# Altium schematic sheet WGPIB.SchDoc(WGPIB)
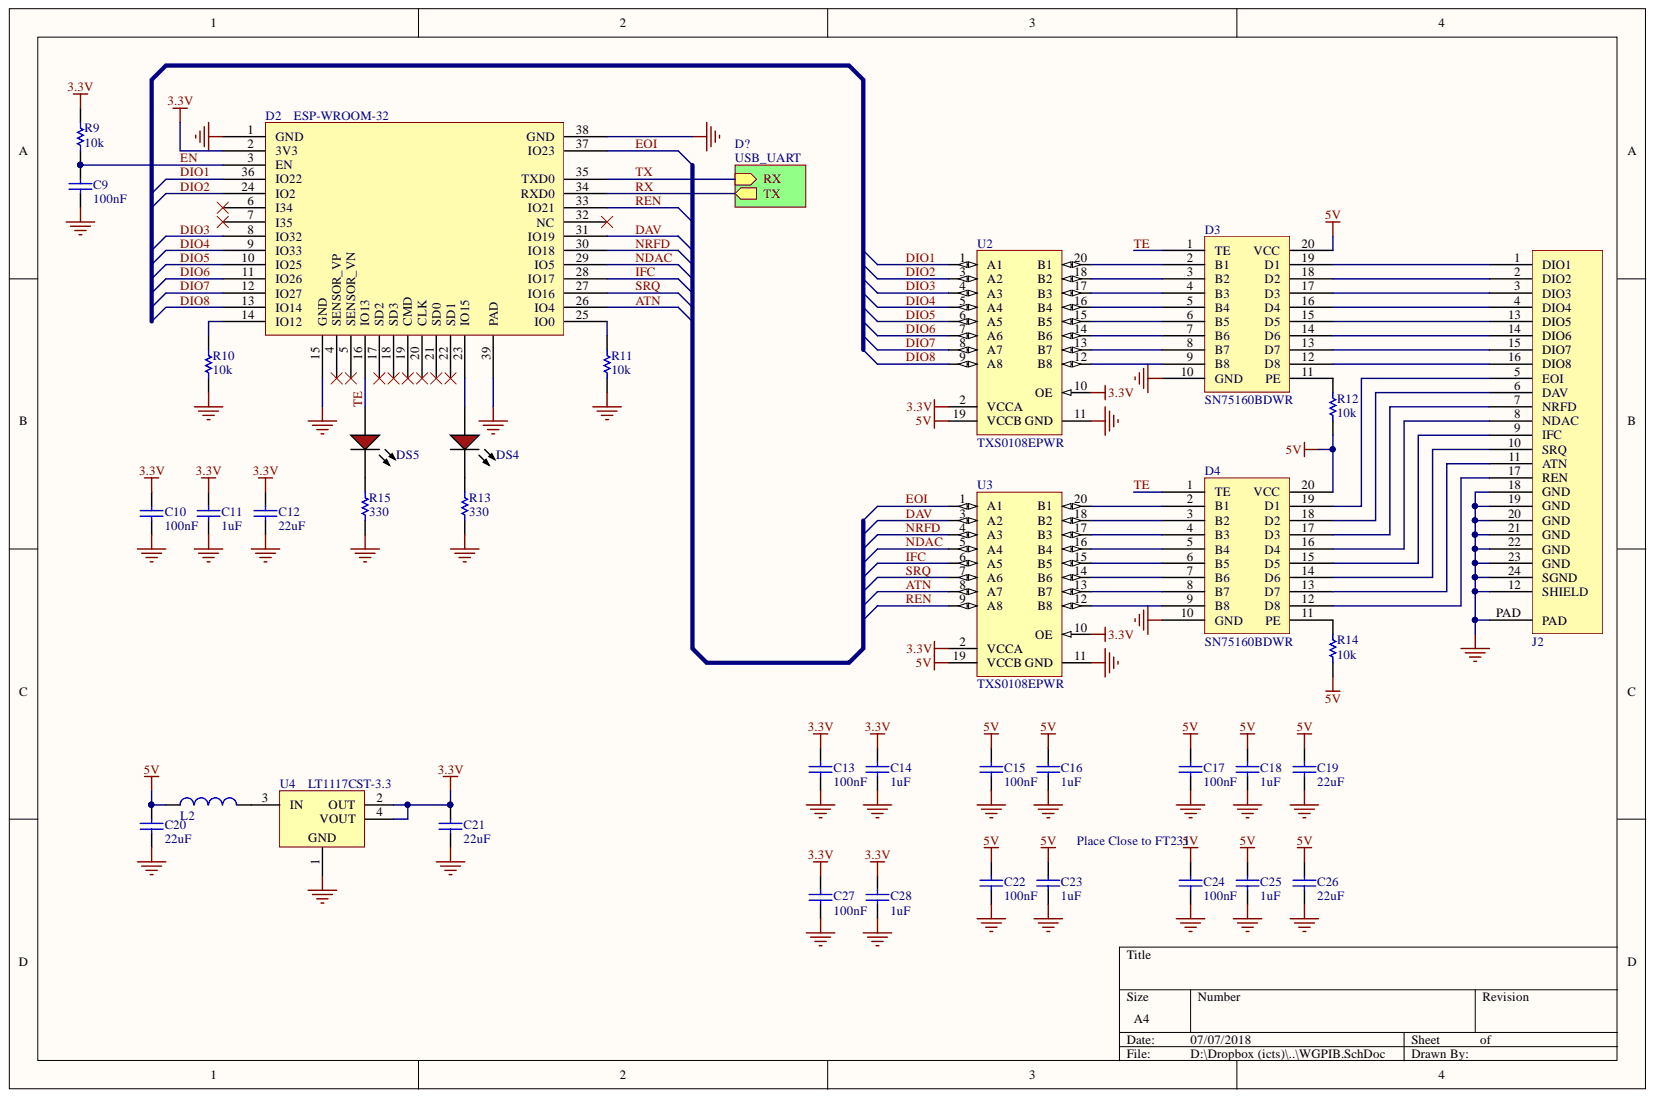
[diagram p0: WGPIB.SchDoc]

## Parts
C9  100nF  [CAPC0805(2012)145_N]
C10  100nF  [CAPC0805(2012)145_N]
C11  1uF  value 100nF  [CAPC0805(2012)145_N]
C12  22uF  [CAPC1206(3216)190_M]
C13  100nF  [CAPC0805(2012)145_N]
C14  1uF  value 100nF  [CAPC0805(2012)145_N]
C15  100nF  [CAPC0805(2012)145_N]
C16  1uF  value 100nF  [CAPC0805(2012)145_N]
C17  100nF  [CAPC0805(2012)145_N]
C18  1uF  value 100nF  [CAPC0805(2012)145_N]
C19  22uF  [CAPC1206(3216)190_M]
C20  22uF  [CAPC1206(3216)190_M]
C21  22uF  [CAPC1206(3216)190_M]
C22  100nF  [CAPC0805(2012)145_N]
C23  1uF  value 100nF  [CAPC0805(2012)145_N]
C24  100nF  [CAPC0805(2012)145_N]
C25  1uF  value 100nF  [CAPC0805(2012)145_N]
C26  22uF  [CAPC1206(3216)190_M]
C27  100nF  [CAPC0805(2012)145_N]
C28  1uF  value 100nF  [CAPC0805(2012)145_N]
D2  ESP-WROOM-32  [ESP-WROOM-32]
D3  SN75160BDWR  [SOIC-20]
D4  SN75160BDWR  [SOIC-20]
DS4  TLMS1100-GS08  [VISH-TLMX1100_V]  (value not drawn)
DS5  TLMS1100-GS08  [VISH-TLMX1100_V]  (value not drawn)
J2  GPIB  [GPIB THT]  (value not drawn)
L2  FBMJ2125HM210NT  [INDC2013X105X50LL20T30]  (value not drawn)
R9  10k  [RESC0805(2012)_M]
R10  10k  [RESC0805(2012)_M]
R11  10k  [RESC0805(2012)_M]
R12  10k  [RESC0805(2012)_M]
R13  330  [RESC0805(2012)_M]
R14  10k  [RESC0805(2012)_M]
R15  330  [RESC0805(2012)_M]
U2  TXS0108EPWR  [PW0020A_M]
U3  TXS0108EPWR  [PW0020A_M]
U4  LT1117CST-3.3  [LT-ST-3-SOT-223_M]

## Nets
3.3V: C10.2 C11.2 C12.2 C13.2 C14.2 C21.2 C27.2 C28.2 D2.2(3V3) R9.2 U2.2(VCCA) U2.10(OE) U3.2(VCCA) U3.10(OE) U4.2(OUT) U4.4(VOUT)
5V: C15.2 C16.2 C17.2 C18.2 C19.2 C20.2 C22.2 C23.2 C24.2 C25.2 C26.2 D3.20(VCC) D4.20(VCC) L2.1 R12.1 R14.1 U2.19(VCCB) U3.19(VCCB)
GND: C9.1 C10.1 C11.1 C12.1 C13.1 C14.1 C15.1 C16.1 C17.1 C18.1 C19.1 C20.1 C21.1 C22.1 C23.1 C24.1 C25.1 C26.1 C27.1 C28.1 D2.1(GND) D2.15(GND) D2.38(GND) D2.39(PAD) D3.10(GND) D4.10(GND) J2.12(SHIELD) J2.18(GND) J2.19(GND) J2.20(GND) J2.21(GND) J2.22(GND) J2.23(GND) J2.24(SGND) J2.PAD R10.1 R11.1 R13.1 R15.1 U2.11(GND) U3.11(GND) U4.1
ATN: D2.26(IO4) U3.8(A7)
DAV: D2.31(IO19) U3.3(A2)
DIO1: D2.36(IO22) U2.1(A1)
DIO2: D2.24(IO2) U2.3(A2)
DIO3: D2.8(IO32) U2.4(A3)
DIO4: D2.9(IO33) U2.5(A4)
DIO5: D2.10(IO25) U2.6(A5)
DIO6: D2.11(IO26) U2.7(A6)
DIO7: D2.12(IO27) U2.8(A7)
DIO8: D2.13(IO14) U2.9(A8)
EN: C9.2 D2.3(EN) R9.1
EOI: D2.37(IO23) U3.1(A1)
IFC: D2.28(IO17) U3.6(A5)
NDAC: D2.29(IO5) U3.5(A4)
NRFD: D2.30(IO18) U3.4(A3)
REN: D2.33(IO21) U3.9(A8)
RX: D2.34(RXD0)
SRQ: D2.27(IO16) U3.7(A6)
TE: D2.16(IO13) D3.1(TE) D4.1(TE) DS5.1
TX: D2.35(TXD0)
NetD2_4: D2.4(SENSOR_VP)
NetD2_5: D2.5(SENSOR_VN)
NetD2_6: D2.6(I34)
NetD2_7: D2.7(I35)
NetD2_14: D2.14(IO12) R10.2
NetD2_17: D2.17(SD2)
NetD2_18: D2.18(SD3)
NetD2_19: D2.19(CMD)
NetD2_20: D2.20(CLK)
NetD2_21: D2.21(SD0)
NetD2_22: D2.22(SD1)
NetD2_23: D2.23(IO15) DS4.1
NetD2_25: D2.25(IO0) R11.2
NetD2_32: D2.32(NC)
NetD3_2: D3.2(B1) U2.20(B1)
NetD3_3: D3.3(B2) U2.18(B2)
NetD3_4: D3.4(B3) U2.17(B3)
NetD3_5: D3.5(B4) U2.16(B4)
NetD3_6: D3.6(B5) U2.15(B5)
NetD3_7: D3.7(B6) U2.14(B6)
NetD3_8: D3.8(B7) U2.13(B7)
NetD3_9: D3.9(B8) U2.12(B8)
NetD3_11: D3.11(PE) R12.2
NetD3_12: D3.12(D8) J2.16(DIO8)
NetD3_13: D3.13(D7) J2.15(DIO7)
NetD3_14: D3.14(D6) J2.14(DIO6)
NetD3_15: D3.15(D5) J2.13(DIO5)
NetD3_16: D3.16(D4) J2.4(DIO4)
NetD3_17: D3.17 J2.3(DIO3)
NetD3_18: D3.18(D2) J2.2(DIO2)
NetD3_19: D3.19(D1) J2.1(DIO1)
NetD4_2: D4.2(B1) U3.20(B1)
NetD4_3: D4.3(B2) U3.18(B2)
NetD4_4: D4.4(B3) U3.17(B3)
NetD4_5: D4.5(B4) U3.16(B4)
NetD4_6: D4.6(B5) U3.15(B5)
NetD4_7: D4.7(B6) U3.14(B6)
NetD4_8: D4.8(B7) U3.13(B7)
NetD4_9: D4.9(B8) U3.12(B8)
NetD4_11: D4.11(PE) R14.2
NetD4_12: D4.12(D8) J2.17(REN)
NetD4_13: D4.13(D7) J2.11(ATN)
NetD4_14: D4.14(D6) J2.10(SRQ)
NetD4_15: D4.15(D5) J2.9(IFC)
NetD4_16: D4.16 J2.8(NDAC)
NetD4_17: D4.17(D3) J2.7(NRFD)
NetD4_18: D4.18(D2) J2.6(DAV)
NetD4_19: D4.19(D1) J2.5(EOI)
NetDS4_2: DS4.2 R13.2
NetDS5_2: DS5.2 R15.2
NetL2_2: L2.2 U4.3(IN)
N00038:
N00039:

## Library data of the parts
C9-C11 C13-C18 C22-C25 C27 C28 = CMP-1036-04431-1: Tolerance: Â±20%; Rated Voltage: 100 V; Case-Metric: 2012; Case-EIA: 0805; Technology: SMT; Max Thickness: 1.45 mm; Library Name: Altium Content Vault; Component Kind: Standard
  C9 C10 C13 C15 C17 C22 C24 C27: Value: 100nF
C12 C19-C21 C26 = CMP-1037-04993-1: Tolerance: Â±20%; Rated Voltage: 16 V; Case-Metric: 3216; Case-EIA: 1206; Value: 22uF; Technology: SMT; Max Thickness: 1.9 mm; Library Name: Altium Content Vault; Component Kind: Standard
D2 = ESP-WROOM-32: ESP32 Module; Library Name: DEV_Modules.SchLib; Component Kind: Standard
DS4 DS5 = CMP-1666-00027-1: LED, RED, 2.1 V, -40 to 100 degC, 2-Pin SMD (0603), RoHS, Tape and Reel; Voltage Rating (DC): 50 V; Type (Capacitors): C0G; Tolerance: 5%; Resistance: 100000000000 Ohm; Capacitance: 12 pF; Width: 0.8 mm; Voltage Rating: 50 V; RoHSCompliant: True; REACH SVHC: No SVHC; Radiation Hardening: No; Pins: 2; Packaging: Tape and Reel; PackageReference: TLMX1100; Package Quantity: 4000; PackageDescription: 2-Pin Surface Mount Device, Body 1.6 x 0.8 mm; Mount: Surface Mount; Min Operating Temperature: -55degC; Max Operating Temperature: 125degC; Library Name: Altium Content Vault; Length: 1.6 mm; Height: 0.8 mm; DatasheetVersion: Rev. 2.7, 03/2016; ComponentLink2URL: http://www.vishay.com/docs/83173/tlmb1100.pdf; ComponentLink2Description: Datasheet; ComponentLink1URL: http://www.vishay.com/; ComponentLink1Description: Manufacturer URL; Component Kind: Standard; Case Code (Metric): 1608; Case Code (Imperial): 0603; Case/Package: 0603
J2 = GPIB: 112-024-113R001; Library Name: DSUB_Connector.SchLib; Component Kind: Standard
L2 = CMP-1660-00003-1: Chip Inductor, 21 Ohm, +/- 30%, 6 A, -40 to 125 degC, 0805 (2012 Metric), RoHS, Tape and Reel; Resistance: 4 mohm; Max Current Rating: 6 A; Impedance: 21 Ohm; Core Material: Ferrite; Case\Package: 0805; Width: 1.25 mm; Tolerance: 30%; RoHSCompliant: true; REACH SVHC: Unknown; Packaging: Tape and Reel; PackageReference: 0805; Package Quantity: 1; PackageDescription: 2-Pin Surface Mount Device, Body 2 x 1.25 mm; Mounting Technology: Surface Mount; Mount: Surface Mount; Min Operating Temperature: -40 degC; Max Operating Temperature: 125 degC; Library Name: Altium Content Vault; Length: 2 mm; Height: 0.85 mm; DatasheetVersion: 08/2016; Current Rating: 6 A; ComponentLink2URL: http://ds.yuden.co.jp/TYCOMPAS/ut/detail.do?productNo=FBMJ2125HM210NT&fileName=FBMJ2125HM210NT_SS&mode=specSheetDownload; ComponentLink2Description: Datasheet; ComponentLink1URL: http://www.t-yuden.com/; ComponentLink1Description: Manufacturer URL; Component Kind: Standard; Case Code (Metric): 2012; Case Code (Imperial): 0805
R9-R15 = CMP-1013-00038-1: Value: 33.00 Ohm; Tolerance: 5 %; Power: 0.125 W; Case-Metric: 2012; Case-EIA: 0805; Technology: SMT; Library Name: Altium Content Vault; Component Kind: Standard
U2 U3 = CMP-2000-04956-1: Bidirectional Voltage-Level Translator for Open-Drain and Push-Pull Application, 8 bit, 1.2 to 3.6 V, -40 to 85 degC, 20-Pin TSSOP, Green (RoHS & no Sb/Br), Tape and Reel; Manufacturer: Texas Instruments; SVHC: NoSVHC(16-Jun-2014); Supply Voltage Min: 1.2V; Supply Voltage Max: 5.5V; Propagation Delay: 4.8ns; Output Current: 620µA; No. of Pins: 20; No. of Inputs: 8; Logic Type: VoltageLevelTranslator; Packaging: CutTape; Operating Temperature Min: -40degC; Operating Temperature Max: 85degC; MSL: MSL1-Unlimited; Manufacturer URL: http://www.ti.com/; Logic Case Style: TSSOP; Library Name: Altium Content Vault; Datasheet Version: Rev. B, 09/2008; Datasheet URL: https://datasheet.ciiva.com/14287/txs0108e-14287280.pdf; Component Kind: Standard
U4 = CMP-0476-01817-1: 0.8 A Low Dropout Positive Regulator, 2.45 to 15 V Vin, 3.3 V Vout, 3-pin SOT223 (ST-3), 0 to 125 degC; Manufacturer: Linear Technology; Vin Min(V): 2.45; Vin Max(V): 15; PSRR(dB): 75; Output Voltage: 3.3 V; Number of Outputs: 1; Isupply(mA): 5; Iout(mA): 800; Dropout Voltage(V): 1.1; Discrete Pass Element: no; RoHS: FALSE; PartNumber: LT1117CST-3.3; PackageVersion: Jun-10; PackageReference: ST-3; PackageDescription: 3-Pin Plastic SOT-223, 3.505 x 6.505 mm Body, Pitch 2.3 mm; Mounting Technology: Surface Mount; Library Name: Altium Content Vault; DatasheetVersion: Rev. D; ComponentLink3URL: http://cds.linear.com/docs/en/packaging/SOT_3_05-08-1630.pdf; ComponentLink3Description: Package Specification; ComponentLink2URL: http://cds.linear.com/docs/en/datasheet/1117fd.pdf; ComponentLink2Description: Datasheet; ComponentLink1URL: http://www.linear.com/; ComponentLink1Description: Manufacturer URL; Component Kind: Standard
D3 D4 = SN75160BDWR: Library Name: LineDrivers.SchLib; Component Kind: Standard
